# Altium schematic sheet [24] - DDR4 MEMORY PS II.SchDoc("[24] - DDR4 MEMORY PS II")
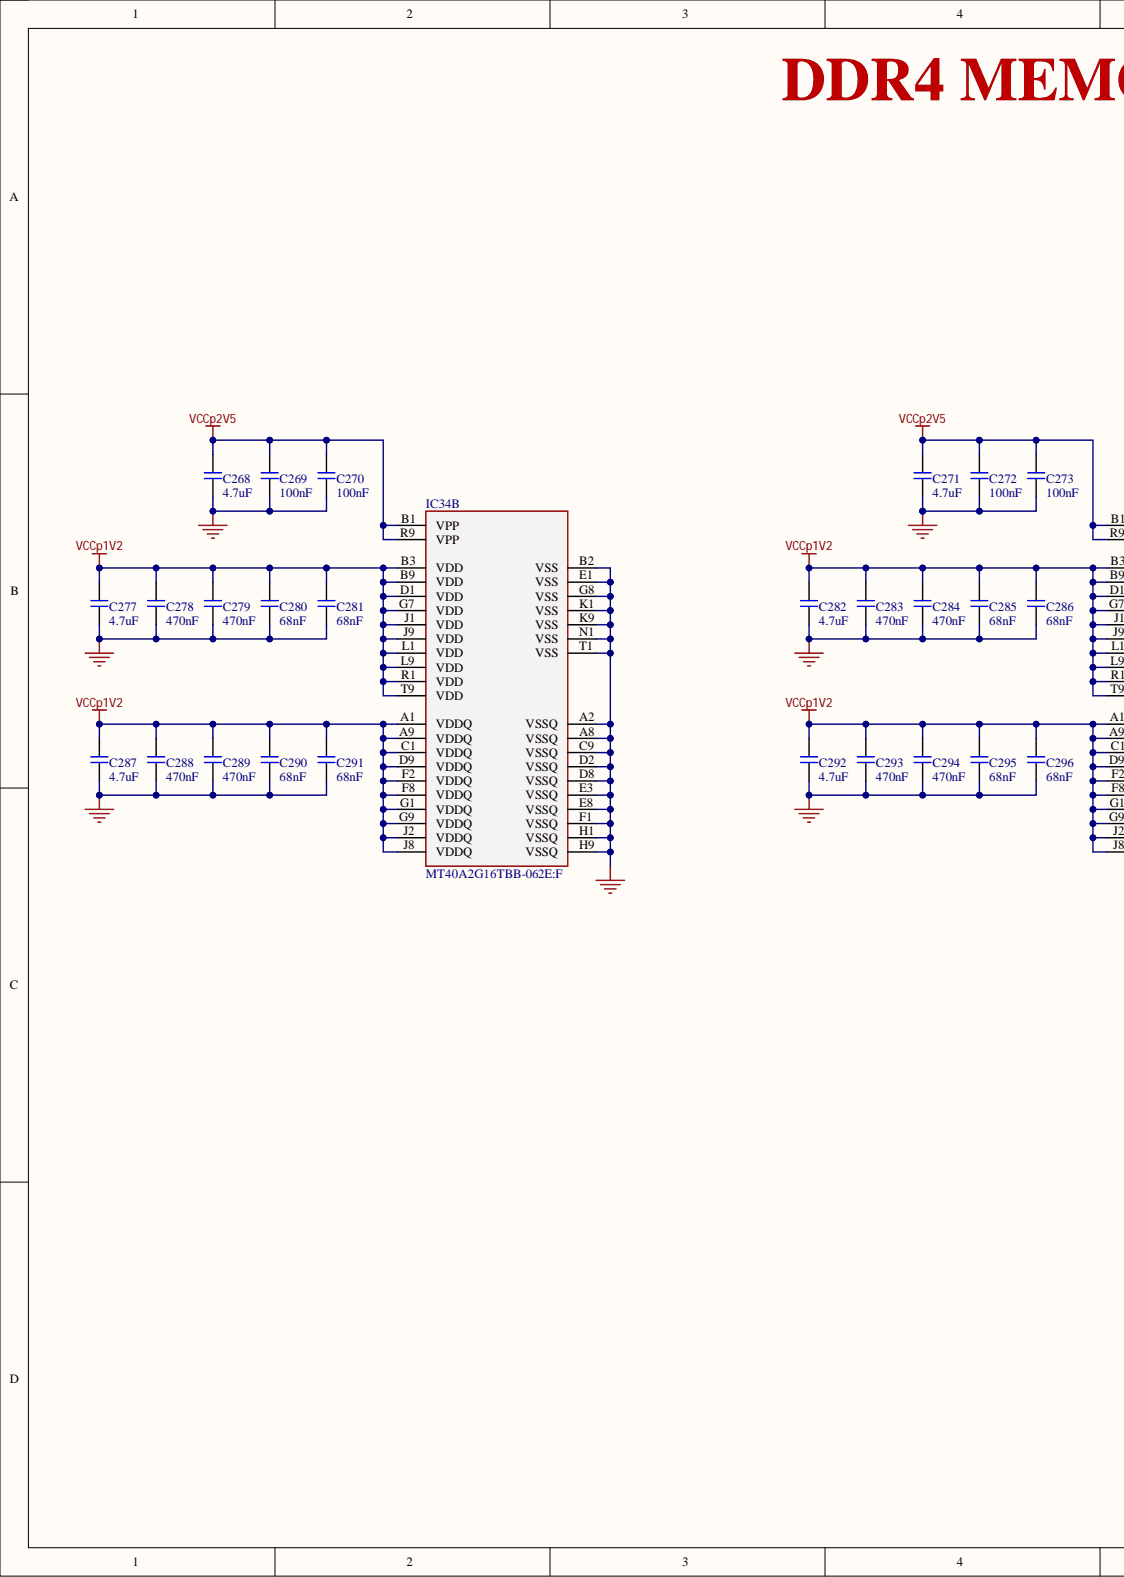
[diagram p0: [24] - DDR4 MEMORY PS II.SchDoc, left]
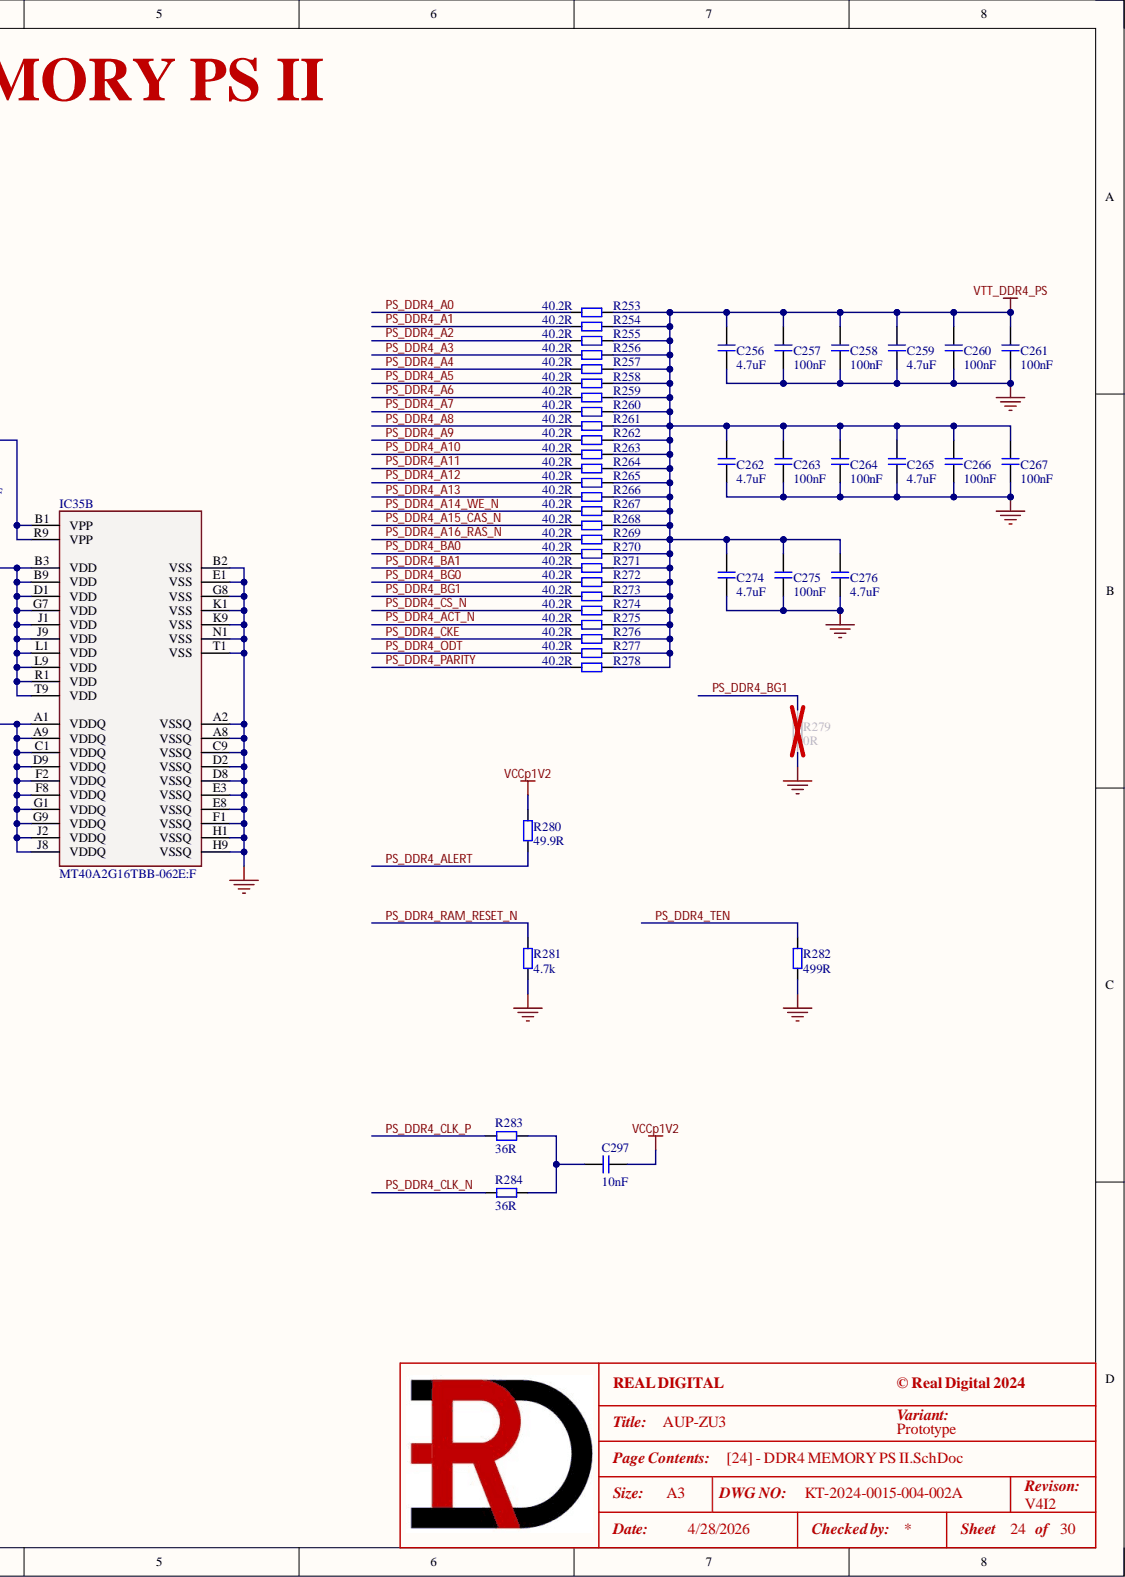
[diagram p1: [24] - DDR4 MEMORY PS II.SchDoc, right]

## Parts
C256  4.7uF  [C0402]
C257  100nF  [C0402]
C258  100nF  [C0402]
C259  4.7uF  [C0402]
C260  100nF  [C0402]
C261  100nF  [C0402]
C262  4.7uF  [C0402]
C263  100nF  [C0402]
C264  100nF  [C0402]
C265  4.7uF  [C0402]
C266  100nF  [C0402]
C267  100nF  [C0402]
C268  4.7uF  [C0402]
C269  100nF  [C0402]
C270  100nF  [C0402]
C271  4.7uF  [C0402]
C272  100nF  [C0402]
C273  100nF  [C0402]
C274  4.7uF  [C0402]
C275  100nF  [C0402]
C276  4.7uF  [C0402]
C277  4.7uF  [C0402]
C278  470nF  [C0402]
C279  470nF  [C0402]
C280  68nF  [C0402]
C281  68nF  [C0402]
C282  4.7uF  [C0402]
C283  470nF  [C0402]
C284  470nF  [C0402]
C285  68nF  [C0402]
C286  68nF  [C0402]
C287  4.7uF  [C0402]
C288  470nF  [C0402]
C289  470nF  [C0402]
C290  68nF  [C0402]
C291  68nF  [C0402]
C292  4.7uF  [C0402]
C293  470nF  [C0402]
C294  470nF  [C0402]
C295  68nF  [C0402]
C296  68nF  [C0402]
C297  10nF  [C0402]
IC34  MT40A2G16TBB-062E:F  [FBGA96_8x14mm - MICRON]  (part B)
IC35  MT40A2G16TBB-062E:F  [FBGA96_8x14mm - MICRON]  (part B)
R253  40.2R  [R0402]
R254  40.2R  [R0402]
R255  40.2R  [R0402]
R256  40.2R  [R0402]
R257  40.2R  [R0402]
R258  40.2R  [R0402]
R259  40.2R  [R0402]
R260  40.2R  [R0402]
R261  40.2R  [R0402]
R262  40.2R  [R0402]
R263  40.2R  [R0402]
R264  40.2R  [R0402]
R265  40.2R  [R0402]
R266  40.2R  [R0402]
R267  40.2R  [R0402]
R268  40.2R  [R0402]
R269  40.2R  [R0402]
R270  40.2R  [R0402]
R271  40.2R  [R0402]
R272  40.2R  [R0402]
R273  40.2R  [R0402]
R274  40.2R  [R0402]
R275  40.2R  [R0402]
R276  40.2R  [R0402]
R277  40.2R  [R0402]
R278  40.2R  [R0402]
R279  0R  [R0402]
R280  49.9R  [R0402]
R281  4.7k  [R0402]
R282  499R  [R0402]
R283  36R  [R0402]
R284  36R  [R0402]

## Nets
GND: C256.1 C257.1 C258.1 C259.1 C260.1 C261.1 C262.1 C263.1 C264.1 C265.1 C266.1 C267.1 C268.1 C269.1 C270.1 C271.1 C272.1 C273.1 C274.1 C275.1 C276.1 C277.1 C278.1 C279.1 C280.1 C281.1 C282.1 C283.1 C284.1 C285.1 C286.1 C287.1 C288.1 C289.1 C290.1 C291.1 C292.1 C293.1 C294.1 C295.1 C296.1 IC34.A2(VSSQ) IC34.A8(VSSQ) IC34.B2(VSS) IC34.C9(VSSQ) IC34.D2(VSSQ) IC34.D8(VSSQ) IC34.E1(VSS) IC34.E3(VSSQ) IC34.E8(VSSQ) IC34.F1(VSSQ) IC34.G8(VSS) IC34.H1(VSSQ) IC34.H9(VSSQ) IC34.K1(VSS) IC34.K9(VSS) IC34.N1(VSS) IC34.T1(VSS) IC35.A2(VSSQ) IC35.A8(VSSQ) IC35.B2(VSS) IC35.C9(VSSQ) IC35.D2(VSSQ) IC35.D8(VSSQ) IC35.E1(VSS) IC35.E3(VSSQ) IC35.E8(VSSQ) IC35.F1(VSSQ) IC35.G8(VSS) IC35.H1(VSSQ) IC35.H9(VSSQ) IC35.K1(VSS) IC35.K9(VSS) IC35.N1(VSS) IC35.T1(VSS) R279.1 R281.1 R282.1
VCCp1V2: C277.2 C278.2 C279.2 C280.2 C281.2 C282.2 C283.2 C284.2 C285.2 C286.2 C287.2 C288.2 C289.2 C290.2 C291.2 C292.2 C293.2 C294.2 C295.2 C296.2 C297.2 IC34.A1(VDDQ) IC34.A9(VDDQ) IC34.B3(VDD) IC34.B9(VDD) IC34.C1(VDDQ) IC34.D1(VDD) IC34.D9(VDDQ) IC34.F2(VDDQ) IC34.F8(VDDQ) IC34.G1(VDDQ) IC34.G7(VDD) IC34.G9(VDDQ) IC34.J1(VDD) IC34.J2(VDDQ) IC34.J8(VDDQ) IC34.J9(VDD) IC34.L1(VDD) IC34.L9(VDD) IC34.R1(VDD) IC34.T9(VDD) IC35.A1(VDDQ) IC35.A9(VDDQ) IC35.B3(VDD) IC35.B9(VDD) IC35.C1(VDDQ) IC35.D1(VDD) IC35.D9(VDDQ) IC35.F2(VDDQ) IC35.F8(VDDQ) IC35.G1(VDDQ) IC35.G7(VDD) IC35.G9(VDDQ) IC35.J1(VDD) IC35.J2(VDDQ) IC35.J8(VDDQ) IC35.J9(VDD) IC35.L1(VDD) IC35.L9(VDD) IC35.R1(VDD) IC35.T9(VDD) R280.2
VCCp2V5: C268.2 C269.2 C270.2 C271.2 C272.2 C273.2 IC34.B1(VPP) IC34.R9(VPP) IC35.B1(VPP) IC35.R9(VPP)
VTT_DDR4_PS: C256.2 C257.2 C258.2 C259.2 C260.2 C261.2 C262.2 C263.2 C264.2 C265.2 C266.2 C267.2 C274.2 C275.2 C276.2 R253.2 R254.2 R255.2 R256.2 R257.2 R258.2 R259.2 R260.2 R261.2 R262.2 R263.2 R264.2 R265.2 R266.2 R267.2 R268.2 R269.2 R270.2 R271.2 R272.2 R273.2 R274.2 R275.2 R276.2 R277.2 R278.2
PS_DDR4_A0: R253.1
PS_DDR4_A1: R254.1
PS_DDR4_A2: R255.1
PS_DDR4_A3: R256.1
PS_DDR4_A4: R257.1
PS_DDR4_A5: R258.1
PS_DDR4_A6: R259.1
PS_DDR4_A7: R260.1
PS_DDR4_A8: R261.1
PS_DDR4_A9: R262.1
PS_DDR4_A10: R263.1
PS_DDR4_A11: R264.1
PS_DDR4_A12: R265.1
PS_DDR4_A13: R266.1
PS_DDR4_A14_WE_N: R267.1
PS_DDR4_A15_CAS_N: R268.1
PS_DDR4_A16_RAS_N: R269.1
PS_DDR4_ACT_N: R275.1
PS_DDR4_ALERT: R280.1
PS_DDR4_BA0: R270.1
PS_DDR4_BA1: R271.1
PS_DDR4_BG0: R272.1
PS_DDR4_BG1: R273.1 R279.2
PS_DDR4_CKE: R276.1
PS_DDR4_CLK_N: R284.1
PS_DDR4_CLK_P: R283.1
PS_DDR4_CS_N: R274.1
PS_DDR4_ODT: R277.1
PS_DDR4_PARITY: R278.1
PS_DDR4_RAM_RESET_N: R281.2
PS_DDR4_TEN: R282.2
NetC297_1: C297.1 R283.2 R284.2

## Library data of the parts
C256 C259 C262 C265 C268 C271 C274 C276 C277 C282 C287 C292 = GRM155R60J475ME47J: CAP CER 4.7uF 6.3V X5R 0402; Manufacturer 1: Murata Electronics; .Checked_PCB: RV; C P/N: RD-111-0022; LoadStatus: Load; Tolerance: 20%; Category: Capacitors; Component Kind: Standard; Library Name: KT-RealDigital.IntLib; Manufacturer 1 Part Number: GRM155R60J475ME47J; Temperature Coefficient: X5R; Voltage - Rated: 6.3V; .Checked_SCH: RV; .Creator: RV
C257 C258 C260 C261 C263 C264 C266 C267 C269 C270 C272 C273 C275 = GRM155R71E104KE14J: CAP CER 0.1uF 25V X7R 0402; Manufacturer 1: Murata Electronics; .Checked_PCB: AC; .Creator: RV; C P/N: RD-111-0006; LoadStatus: Load; Tolerance: 10%; Category: Capacitors; Component Kind: Standard; Library Name: KT-RealDigital.IntLib; Manufacturer 1 Part Number: GRM155R71E104KE14J; Temperature Coefficient: X7R; Voltage - Rated: 25V; .Checked_SCH: AC
C278 C279 C283 C284 C288 C289 C293 C294 = GRM155R61H474KE11D: CAP CER 0.47uF 50V X5R 0402; Manufacturer 1: Murata Electronics; .Checked_PCB: RV; C P/N: RD-111-0033; LoadStatus: Load; Tolerance: 10%; Category: Capacitors; Component Kind: Standard; Library Name: KT-RealDigital.IntLib; Manufacturer 1 Part Number: GRM155R61H474KE11D; Temperature Coefficient: X5R; Voltage - Rated: 50V; .Checked_SCH: RV; .Creator: RV
C280 C281 C285 C286 C290 C291 C295 C296 = GCM155R71C683KA55D: CAP CER 0.068uF 16V X7R 0402; Manufacturer 1: Murata Electronics; .Checked_PCB: RV; C P/N: RD-111-0034; LoadStatus: Load; Tolerance: 10%; Category: Capacitors; Component Kind: Standard; Library Name: KT-RealDigital.IntLib; Manufacturer 1 Part Number: GCM155R71C683KA55D; Temperature Coefficient: X7R; Voltage - Rated: 16V; .Checked_SCH: RV; .Creator: RV
C297 = GRM155R71H103KA88J: CAP CER 10000pF 50V X7R 0402; Manufacturer 1: Murata Electronics; .Checked_PCB: AC; .Creator: RV; C P/N: RD-111-0003; LoadStatus: Load; Tolerance: 10%; Category: Capacitors; Component Kind: Standard; Library Name: KT-RealDigital.IntLib; Manufacturer 1 Part Number: GRM155R71H103KA88J; Temperature Coefficient: X7R; Voltage - Rated: 50V; .Checked_SCH: AC
IC34 IC35 = MT40A2G16TBB-062E:F: IC DRAM 32GBIT PARALLEL 96FBGA (TwinDie); Manufacturer 1: Micron Technology Inc.; .Checked_PCB: RV; .Creator: DG; C P/N: RD-126-1528; LoadStatus: Load; Category: IC; Component Kind: Standard; Library Name: KT-RealDigital.IntLib; Manufacturer 1 Part Number: MT40A2G16TBB-062E:F; .Checked_SCH: RV
R253-R278 = RC0402FR-0740R2L: RES SMD 40.2 OHM 1% 1/16W 0402; Manufacturer 1: Yageo; .Checked_PCB: RV; C P/N: RD-101-0042; LoadStatus: Load; Tolerance: 1%/TK100; Category: Resistors; Component Kind: Standard; Library Name: KT-RealDigital.IntLib; Manufacturer 1 Part Number: RC0402FR-0740R2L; .Checked_SCH: RV; .Creator: RV
R279 = RC0402FR-070RL: RES SMD 0 OHM JUMPER 1/16W 0402; Manufacturer 1: YAGEO; .Checked_PCB: AC; .Creator: RV; C P/N: RD-101-0003; LoadStatus: Load; Category: Resistors; Component Kind: Standard; Library Name: KT-RealDigital.IntLib; Manufacturer 1 Part Number: RC0402FR-070RL; Tolerance: Jumper; .Checked_SCH: AC
R280 = RC0402FR-0749R9L: RES SMD 49.9 OHM 1% 1/16W 0402; Manufacturer 1: Yageo; .Checked_PCB: RV; C P/N: RD-101-0016; LoadStatus: Load; Tolerance: 1%/TK100; Category: Resistors; Component Kind: Standard; Library Name: KT-RealDigital.IntLib; Manufacturer 1 Part Number: RC0402FR-0749R9L; .Checked_SCH: RV; .Creator: RV
R281 = RC0402FR-074K7P: RES SMD 4.7K OHM 1% 1/16W 0402; Manufacturer 1: Yageo; .Checked_PCB: AC; .Creator: RV; C P/N: 101-0129; LoadStatus: Load; Tolerance: 1%/TK100; Category: Resistors; Component Kind: Standard; Library Name: KT-RealDigital.IntLib; Manufacturer 1 Part Number: RC0402FR-074K7P; .Checked_SCH: AC
R282 = RC0402FR-07499RL: RES SMD 499 OHM 1% 1/16W 0402; Manufacturer 1: Yageo; .Checked_PCB: RV; C P/N: RD-101-0036; LoadStatus: Load; Tolerance: 1%/TK100; Category: Resistors; Component Kind: Standard; Library Name: KT-RealDigital.IntLib; Manufacturer 1 Part Number: RC0402FR-07499RL; .Checked_SCH: RV; .Creator: RV
R283 R284 = RC0402FR-0736RL: RES SMD 36 OHM 1% 1/16W 0402; Manufacturer 1: Yageo; .Checked_PCB: RV; C P/N: RD-101-0043; LoadStatus: Load; Tolerance: 1%/TK100; Category: Resistors; Component Kind: Standard; Library Name: KT-RealDigital.IntLib; Manufacturer 1 Part Number: RC0402FR-0736RL; .Checked_SCH: RV; .Creator: RV
